# Altium schematic sheet drvoutput0.SchDoc(drvoutput0)
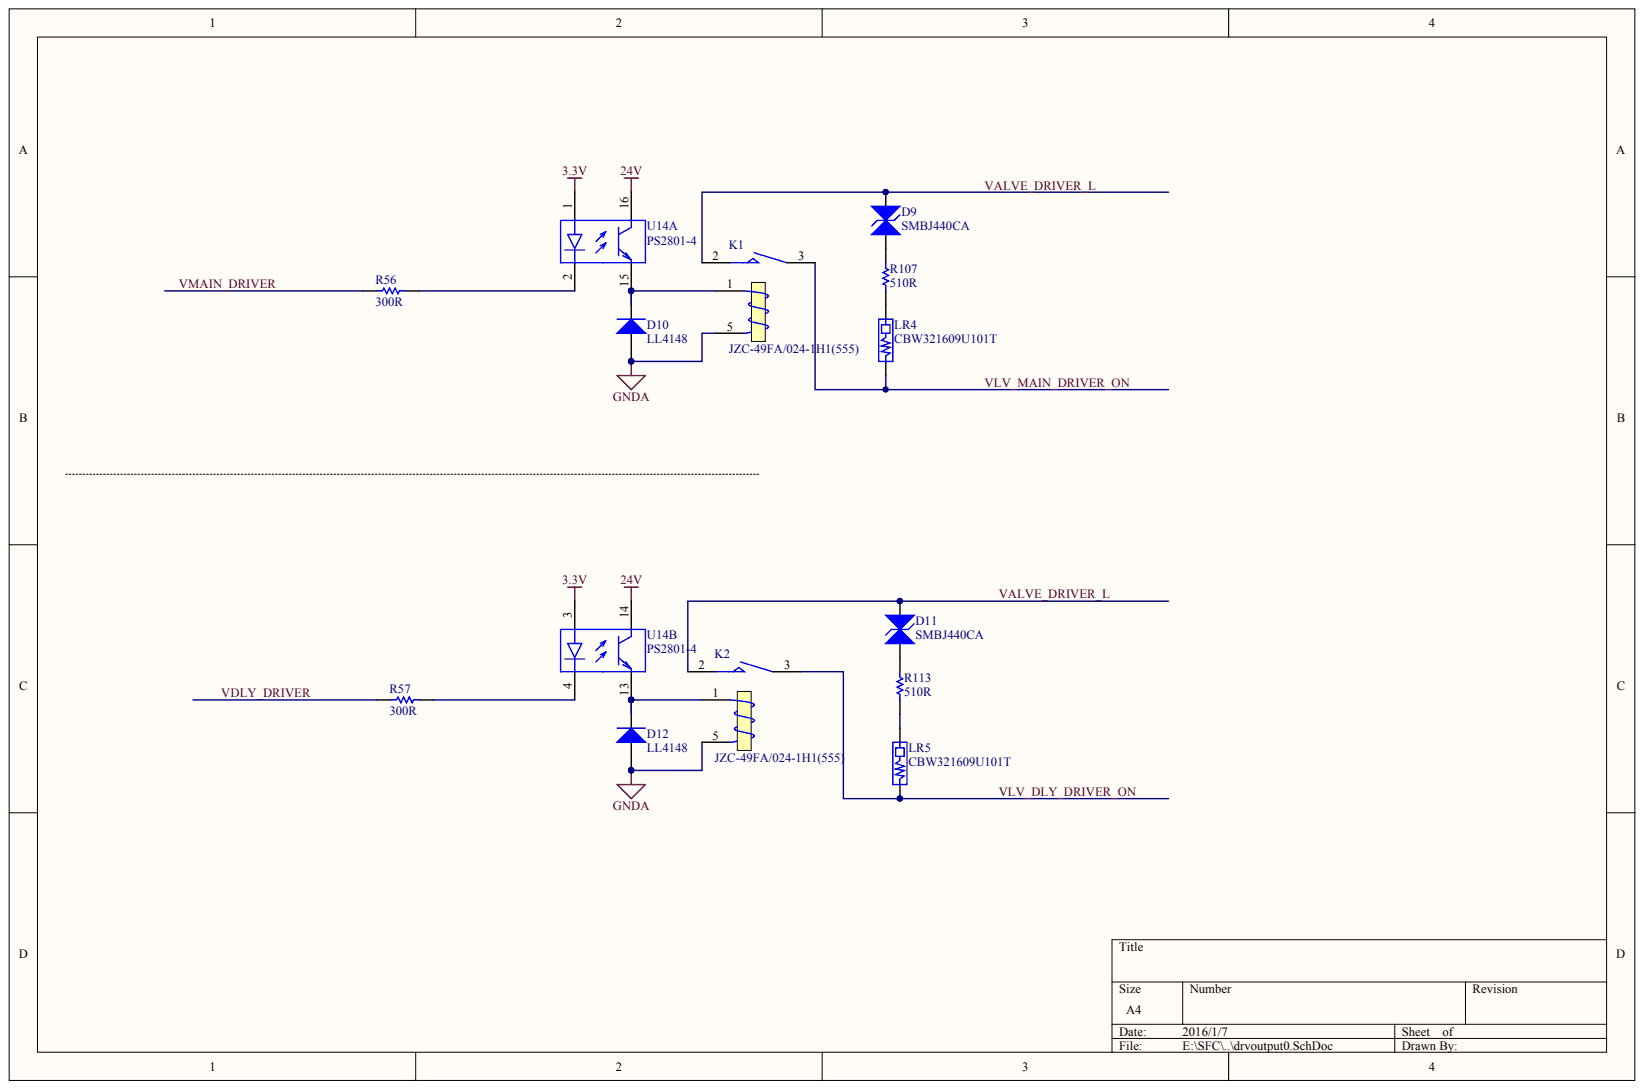
[diagram p0: drvoutput0.SchDoc]

## Parts
D9  SMBJ440CA
D10  LL4148
D11  SMBJ440CA
D12  LL4148
K1  JZC-49FA/024-1H1(555)
K2  JZC-49FA/024-1H1(555)
LR4  CBW321609U101T
LR5  CBW321609U101T
R56  300R
R57  300R
R107  510R
R113  510R
U14  PS2801-4  (parts A,B)

## Nets
3.3V: U14.1 U14.3
24V: U14.14 U14.16
GNDA: D10.1 D12.1 K1.5 K2.5
VALVE_DRIVER_L: D9.2 D11.2 K1.2 K2.2
VDLY_DRIVER: R57.1
VLV_DLY_DRIVER_ON: K2.3 LR5.1
VLV_MAIN_DRIVER_ON: K1.3 LR4.1
VMAIN_DRIVER: R56.1
NetD9_1: D9.1 R107.2
NetD10_2: D10.2 K1.1 U14.15
NetD11_1: D11.1 R113.2
NetD12_2: D12.2 K2.1 U14.13
NetLR4_2: LR4.2 R107.1
NetLR5_2: LR5.2 R113.1
NetR56_2: R56.2 U14.2
NetR57_2: R57.2 U14.4

## Library data of the parts
R56 R57 R107 R113 = (no symbol name): Value: 10K; Published: 8-Jun-2000; LatestRevisionDate: 17-Jul-2002; LatestRevisionNote: Re-released for DXP Platform.; PackageReference: AXIAL-0.3; Publisher: Altium Limited
D9-D12 K1 K2 LR4 LR5 U14 = (no symbol name)
  D9 D11: Published: 8-Jun-2000; LatestRevisionDate: 17-Jul-2002; LatestRevisionNote: Re-released for DXP Platform.; PackageReference: DIODE-0.7
  D10 D12: Published: 8-Jun-2000; LatestRevisionDate: 17-Jul-2002; LatestRevisionNote: Re-released for DXP Platform.; DatasheetVersion: 1998; PackageVersion: Aug-1999; PackageReference: DO-41; Code_JEDEC: DO-204-AL; ComponentLink1Description: Manufacturer Link; ComponentLink1URL: http://www.fairchildsemi.com/; ComponentLink2Description: Datasheet; ComponentLink2URL: http://www.fairchildsemi.com/ds/1N%2F1N4001.pdf
  K1 K2: Published: 8-Jun-2000; LatestRevisionDate: 17-Jul-2002; LatestRevisionNote: Re-released for DXP Platform.; PackageReference: MODULE5B
  U14: Published: 28-Feb-2003; LatestRevisionDate: 13-Apr-2006; LatestRevisionNote: IPC-7351 Footprint Added.; DatasheetVersion: Jan-2002, Rev. 7; PackageVersion: rev7, Jan-2002; PackageReference: 751-07; PackageDescription: 8-Pin Plastic Small Outline Integrated Circuit 1.27 mm Pitch; Code_JEDEC: MS-012-AA; Code_IPC: SOIC127P600-8; ComponentLink1Description: Manufacturer Link; ComponentLink1URL: http://www.onsemi.com/; ComponentLink2Description: Datasheet; ComponentLink2URL: http://www.onsemi.com/pub/Collateral/LM358-D.PDF
  D9-D12 K1 K2 U14: Publisher: Altium Limited
